# Altium schematic sheet XMOD_FT2232H.SchDoc(XMOD_FT2232H)
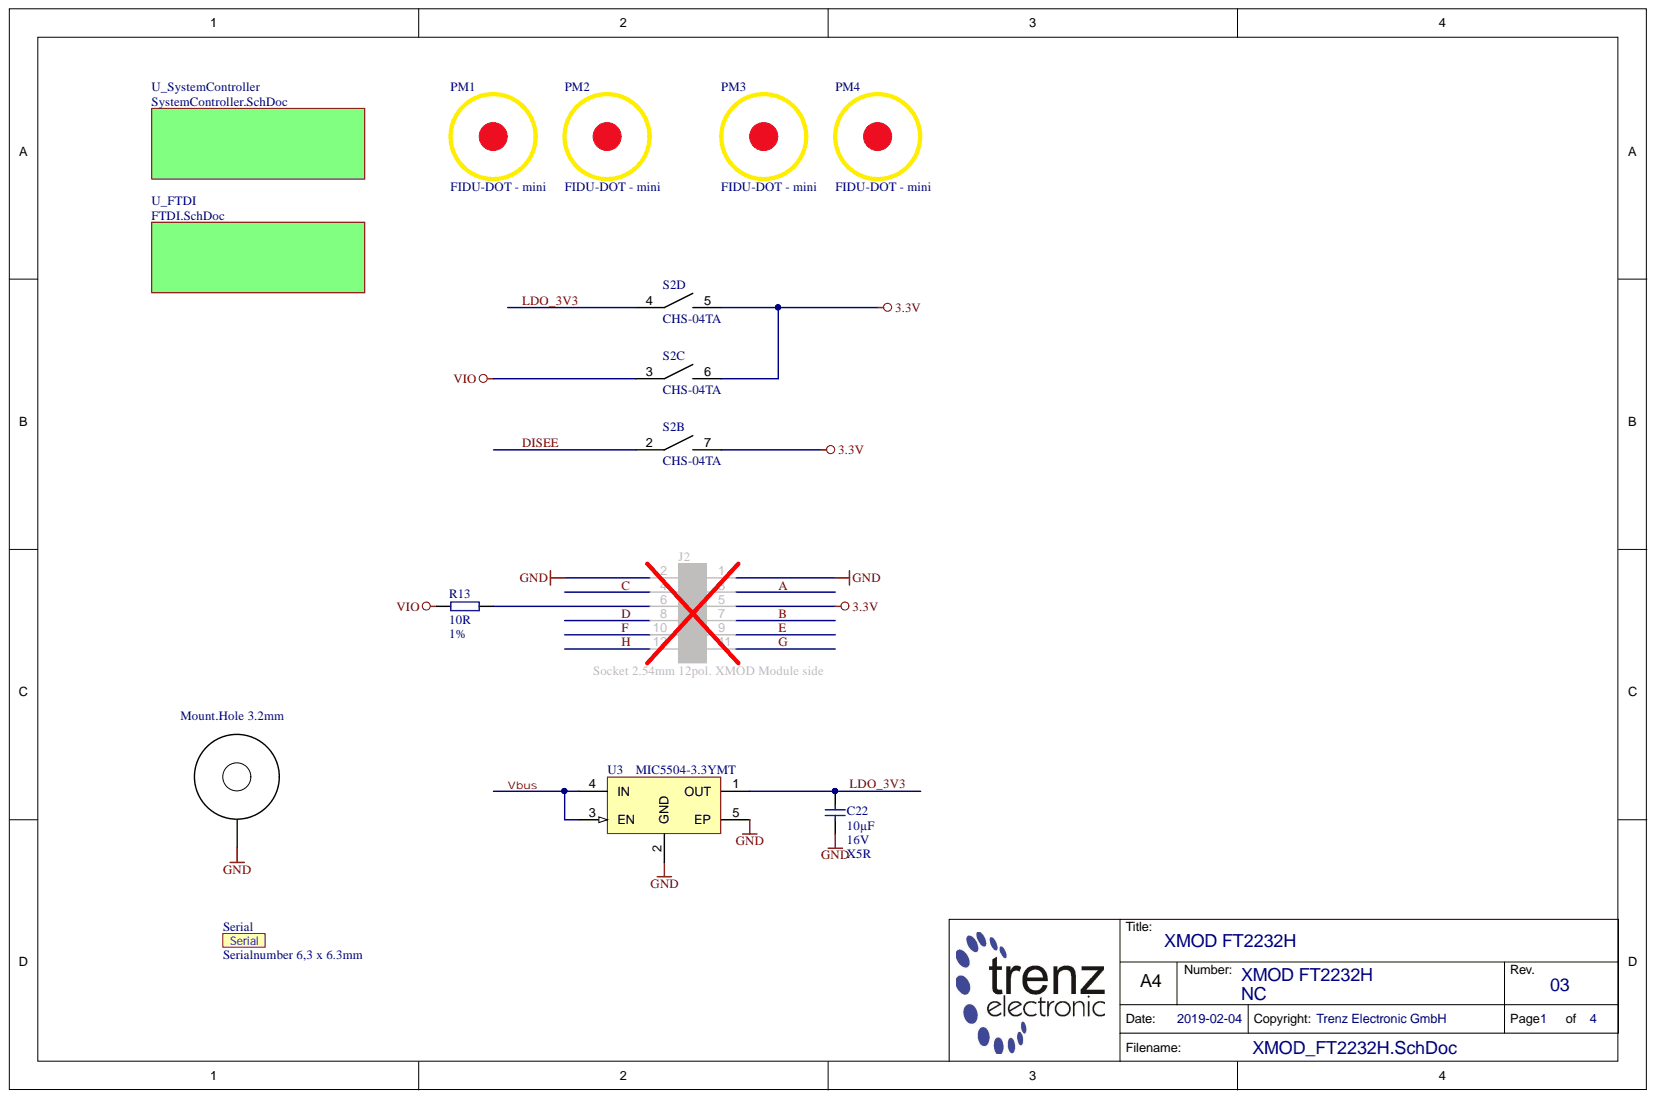
[diagram p0: XMOD_FT2232H.SchDoc]

## Parts
C22  10µF  Voltage: 16V  Technology: X5R  [CAPC1608X90N]
H5  Mount.Hole 3.2mm  [MHole3_2mm]  (no BOM)
J2  Socket 2.54mm 12pol. XMOD Module side  [BUCHSENLEISTE-2.54-12-2R_XMOD_CUST]
PM1  FIDU-DOT - mini  [FIDU-DOT - mini]
PM2  FIDU-DOT - mini  [FIDU-DOT - mini]
PM3  FIDU-DOT - mini  [FIDU-DOT - mini]
PM4  FIDU-DOT - mini  [FIDU-DOT - mini]
R13  10R  Tolerance: 1%  [RESC1005X40N]
S2  CHS-04TA  [CHS-04TA]  (parts B,C,D)
Serial  Serialnumber 6,3 x 6.3mm  [Serial_A_6.3x6.3mm]  (no BOM)
U3  MIC5504-3.3YMT  [MIC5504-3.3YMT]

## Nets
3.3V: J2.5 S2.5 S2.6 S2.7
GND: C22.2 H5.1 J2.1 J2.2 U3.2(GND) U3.5(EP)
VIO: R13.1 S2.3
A: J2.3
B: J2.7
C: J2.4
D: J2.8
DISEE: S2.2
E: J2.9
F: J2.10
G: J2.11
H: J2.12
LDO_3V3: C22.1 S2.4 U3.1(OUT)
Vbus: U3.3(EN) U3.4(IN)
NetJ2_6: J2.6 R13.2

## Library data of the parts
C22 = 25341: KON 10µF 16V X5R 20% SMD BF 1608 (0603); Value: 10u; Temperature-Range: -55/+85; Preferred: Yes; Mixed: SMT; Database Table Name: C_1608_0603; Tolerance: 20%; Library Name: TE.DbLib; Component Kind: Standard; Case: 1608 (0603); AN: 25341
H5 = 22898: Mixed: THT; Database Table Name: PCB; Library Name: TE.DbLib; Description: Mount.Hole 3.2mm; AN: 22898
J2 = 29517: Buchsenleiste 2 reihig präz. 12pol. XMOD Module side; Trenz-Article-Number: 22779; Mixed: THT; Database Table Name: CON_HEADER; Library Name: TE.DbLib; Component Kind: Standard; AN: 29517; HelpURL: B:\AD\adlib\datasheet\153
PM1-PM4 = 27037: FIDU-DOT - MINI 0.5mm; Mixed: FIDU; Database Table Name: PCB; Library Name: TE.DbLib; Component Kind: Standard; AN: 27037
R13 = 22844: WID SMD 10R 1% 0,063W  BF 1005 (0402); Value: 10; Preferred: Yes; Mixed: SMT; H-ART: RC0402FR-0710RL; Database Table Name: R_1005_0402; Technology: TK100; Library Name: TE.DbLib; Component Kind: Standard; Case: 1005 (0402); AN: 22844
S2 = 23417: DIP Schalter 4 fach 1,27mm SMD; Trenz-Stock: Yes; Mixed: SMT; Database Table Name: PB_SW; Preferred: Yes; Library Name: TE.DbLib; H-ART: CHS-04TA; Component Kind: Standard; AN: 23417; HelpURL: B:\AD\adlib\datasheet\CHS
Serial = 26782: Serialnumber (AD only)  6,3 x 6.3mm; Trenz-Article-Number: 26753; Mixed: MECH; Database Table Name: PCB; Library Name: TE.DbLib; AN: 26782
U3 = 28312: Voltage: 3.3V; Mixed: SMT; Database Table Name: LREG; Library Name: TE.DbLib; H-ART: MIC5504-3.3YMT; Description: MIC5504-3.3YMT; Component Kind: Standard; Case: UDFN4; AN: 28312; HelpURL: b:\AD\adlib\datasheet\MIC550x\
